# Altium schematic sheet USB.SchDoc
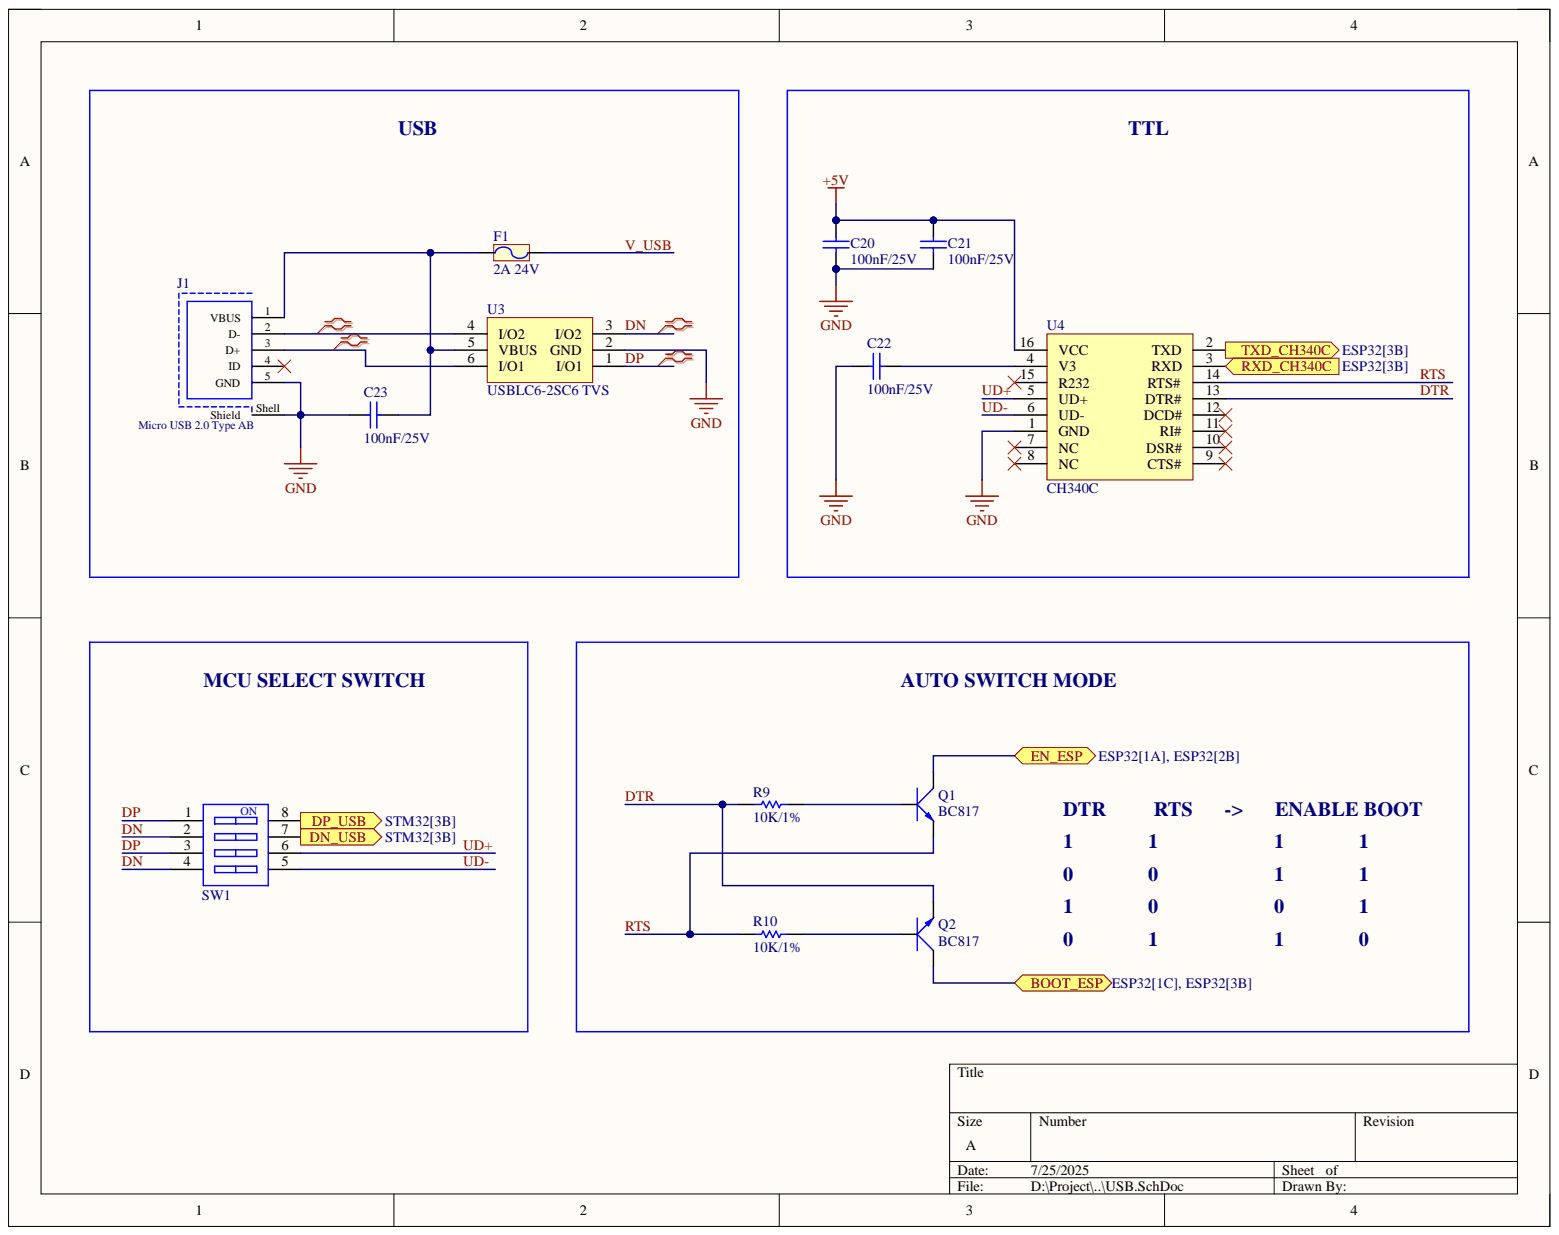
[diagram p0: USB.SchDoc]

## Parts
C20  value 100nF/25V  [CAP-SM-0805]  (hidden comment: Cap Non-Pol SMD)
C21  value 100nF/25V  [CAP-SM-0805]  (hidden comment: Cap Non-Pol SMD)
C22  value 100nF/25V  [CAP-SM-0805]  (hidden comment: Cap Non-Pol SMD)
C23  value 100nF/25V  [CAP-SM-0805]  (hidden comment: Cap Non-Pol SMD)
F1  2A 24V  [Cau chi tu phuc hoi 2A 24V SMD1812]
J1  Micro USB 2.0 Type AB  [629105150921]
Q1  BC817  [SOT-23]
Q2  BC817  [SOT-23]
R9  value 10K/1%  [RES-SM-0805]  (hidden comment: RESISTOR SMD)
R10  value 10K/1%  [RES-SM-0805]  (hidden comment: RESISTOR SMD)
SW1  418117270904  [418117270904]  (value not drawn)
U3  USBLC6-2SC6 TVS  [SOT23-6]
U4  CH340C  [SOIC16_50_284_25X90_SMT]

## Ports
BOOT_ESP, DN_USB, DP_USB, EN_ESP, RXD_CH340C, TXD_CH340C

## Nets
+5V: C20.1 C21.1 U4.16(VCC)
GND: C20.2 C21.2 C22.2 C23.1 J1.5(GND) J1.Shell(Shield) U3.2(GND) U4.1(GND)
BOOT_ESP: Q2.3 ; port BOOT_ESP
DN: SW1.2 SW1.4 U3.3(I/O2)
DN_USB: SW1.7 ; port DN_USB
DP: SW1.1 SW1.3 U3.1(I/O1)
DP_USB: SW1.8 ; port DP_USB
DTR: Q2.2 R9.1 U4.13(DTR#)
EN_ESP: Q1.3 ; port EN_ESP
RTS: Q1.2 R10.1 U4.14(RTS#)
RXD_CH340C: U4.3(RXD) ; port RXD_CH340C
TXD_CH340C: U4.2(TXD) ; port TXD_CH340C
UD+: SW1.6 U4.5(UD+)
UD-: SW1.5 U4.6(UD-)
V_USB: F1.2
NetC22_1: C22.1 U4.4(V3)
NetC23_2: C23.2 F1.1 J1.1(VBUS) U3.5(VBUS)
NetJ1_2: J1.2(D-) U3.4(I/O2)
NetJ1_3: J1.3(D+) U3.6(I/O1)
NetQ1_1: Q1.1 R9.2
NetQ2_1: Q2.1 R10.2

Not connected: J1 4(ID); U4 7(NC) 8(NC) 9(CTS#) 10(DSR#) 11(RI#) 12(DCD#) 15(R232)

## Library data of the parts
C20-C23 = Cap Non-Pol SMD: Capacitor; Component Kind: Standard; Library Name: PIF_Library.IntLib
F1 = Cau chi tu phuc hoi 2A 24V SMD1812: 2A 24V SMD1812; Ma_Hang: MH010033; Ten: Cầu Chì Tự Phục Hồi 2A 24V SMD1812; Component Kind: Standard; Library Name: Altium_Lib_by_KhueNguyenCreator.IntLib
J1 = 629105150921: Micro USB 2.0 Type AB Receptacle WR-COM, Horizontal, SMT; Contact Resistance: 30 mOhm; Rated Current: 1.8 A; Working Voltage: 30 VAC; Component Kind: Standard; ComponentLink1Description: Datasheet; ComponentLink1URL: https://katalog.we-online.de/em/datasheet/629105150921.pdf; ComponentLink2Description: Supplier 1 URL; ComponentLink2URL: N/A; ComponentLink3Description: Supplier 2 URL; ComponentLink3URL: N/A; ComponentLink4Description: Supplier 3 URL; ComponentLink4URL: N/A; Connector Type: Micro USB 2.0 Type AB; Gender: Receptacle; Library Name: Altium_USB.IntLib; Mounting Technology: SMT; Operating Temperature: -40 to 85 degC; Orientation: Horizontal; Packaging: Tape and Reel; Part Number: 629105150921; Published Date: September 2018; Supplier 1 Name: Mouser; Supplier 1 SN: 710-629105150921; Supplier 1 URL: https://www.mouser.vn/ProductDetail/Wurth-Elektronik/629105150921?qs=a9WhcLg8qCztfCBvRE9gEg%3D%3D; Supplier 2 Name: Digi-Key; Supplier 2 SN: N/A; Supplier 2 URL: N/A; Supplier 3 Name: thegioiic; Supplier 3 SN: N/A; Supplier 3 URL: N/A; Value: Micro USB 2.0 Type AB; Publisher: Wurth Elektronik
Q1 Q2 = Trans BC817: SOT-23 - C8658; Value: C9014; Component Kind: Standard; Library Name: Altium_Lib_by_KhueNguyenCreator.IntLib
R9 R10 = RESISTOR SMD: Resistor; Component Kind: Standard; Library Name: PIF_Library.IntLib
SW1 = 418117270904: WS-DITV Compact THT Dip Switch, Raised Actuator, pitch 2.54mm, 4p; Manufacturer: Wurth Elektronik; Actuator Type: Raise Type; Number of Poles: 4; Operation Force: 800g; Rated Current Non-Switching: 100mA; Rated Current Switching: 25mA; Rated Voltage Non-Switching: 50VDC; Rated Voltage Switching: 24VDC; Category: Dip Switches; Component Kind: Standard; ComponentLink2Description: Datasheet Link; ComponentLink2URL: https://www.we-online.com/redexpert/spec/418117270904?ad; Contact Material: Copper Alloy; Contact Plating: Gold; Library Name: Altium_Button.IntLib; Manufacturer Part Number: 418117270904; Match Code: WS-DITV; Mount: Through Hole; Operating Temperature Max: 85°C; Operating Temperature Min: -40°C; Packaging: Tube; Pitch: 2.54mm; Supplier 1 Name: Mouser; Supplier 1 SN: 710-418117270904; Supplier 1 URL: https://www.mouser.com/ProductDetail/Wurth-Elektronik/418117270904?qs=wr8lucFkNMUW2I6n2hVTKw%3D%3D; Supplier 2 Name: Digi-Key; Supplier 2 SN: 732-3834-5-ND; Supplier 2 URL: https://www.digikey.com/en/products/detail/w%C3%BCrth-elektronik/418117270904/3174489; Supplier 3 Name: Thegioiic; Supplier 3 SN: N/A; Supplier 3 URL: https://www.thegioiic.com/cong-tac-4-bit-dip-switch-xuyen-lo-2-54mm-mau-do
U3 = IC_LTC6992IS6-1: Oscillator, Silicon IC 3.81Hz ~ 1MHz TSOT-23-6; Alternate Part Number-1: NA; Manufacturer: Linear Technology/Analog Devices; Case/Package: SOT-23-6; Component Kind: Standard; Manufacturer Part Number: LTC6992IS6-1; Supplier Part Number-1: LTC6992IS6-1#TRMPBF; Supplier-1: Arrow; Library Name: Altium_Lib_by_KhueNguyenCreator.IntLib
U4 = CH340C: USB Bridge, USB to UART USB 2.0 UART Interface; Alternate Part Number-1: NA; Manufacturer: Olimex; Case/Package: SOIC16; Component Kind: Standard; Manufacturer Part Number: CH340C; Supplier Part Number-1: C84681; Supplier-1: LCSC; Library Name: Altium_Lib_by_KhueNguyenCreator.IntLib
